# Altium schematic sheet GTL3_Inverter.SchDoc
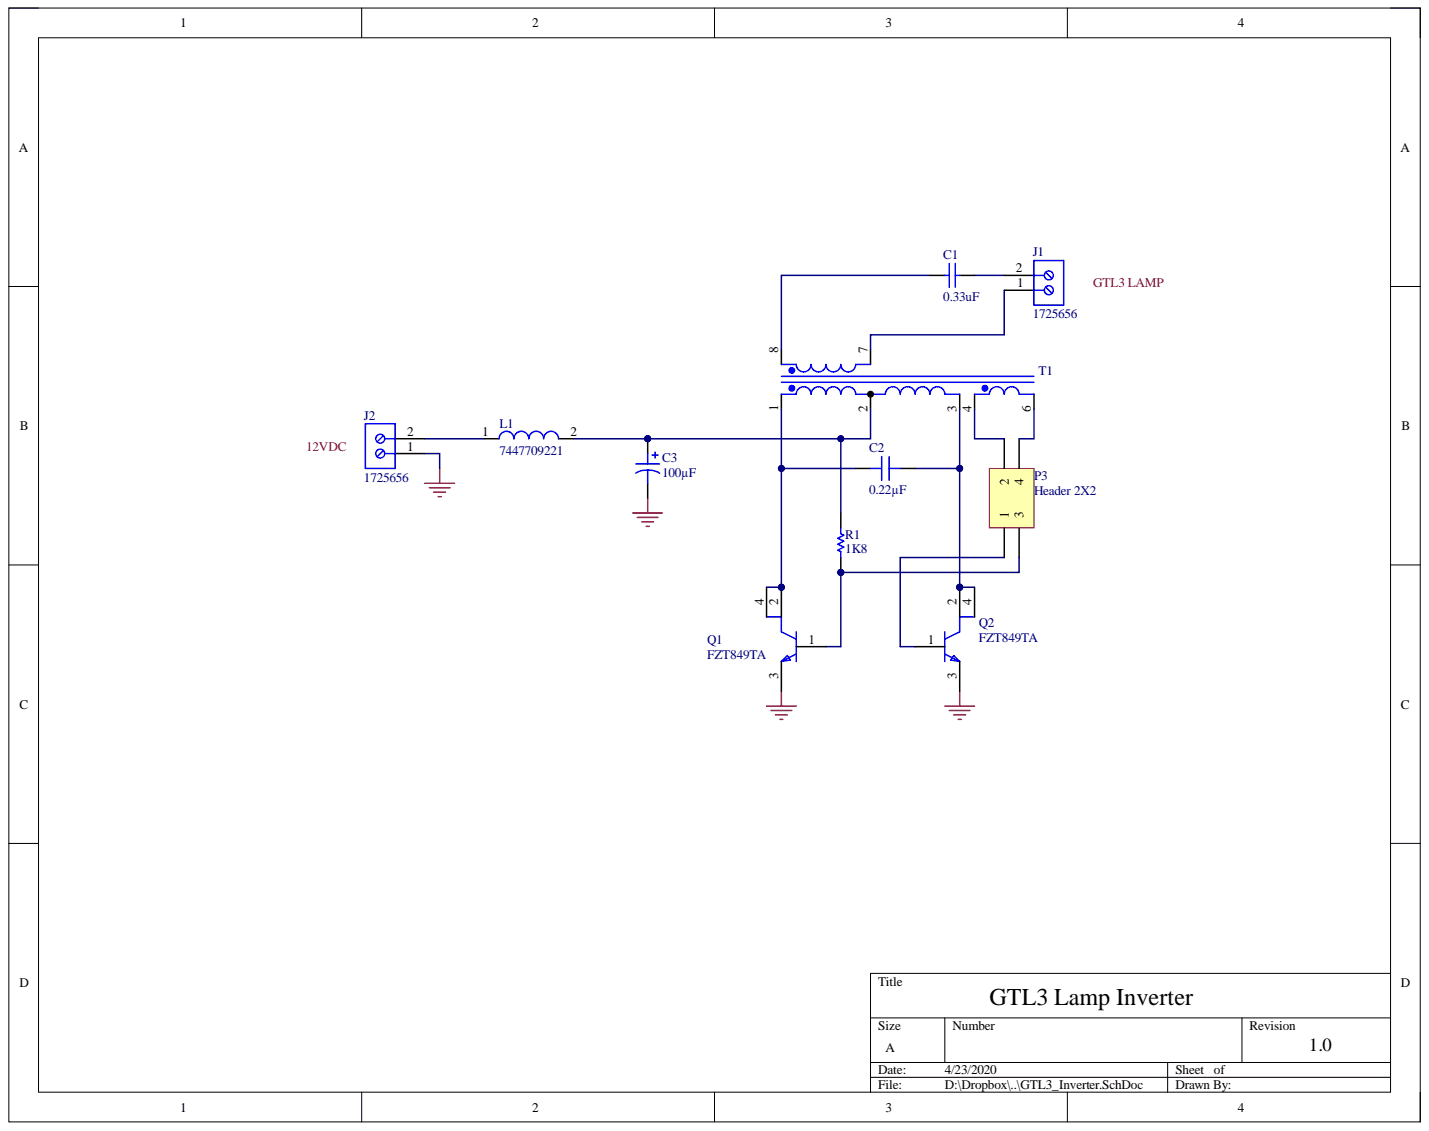
[diagram p0: GTL3_Inverter.SchDoc]

## Parts
C1  0.33uF  [CAPC2220(5750)230_L]
C2  0.22µF  [CAP FILM 2416]
C3  100µF  [CAP ALSMD 8.3MM H10.5MM]
J1  1725656  [PHOE-1725656-2]
J2  1725656  [PHOE-1725656-2]
L1  7447709221  [WE-PD-XXL]
P3  Header 2X2  [HDR2X2]
Q1  FZT849TA  [FP-SOT223-MFG]
Q2  FZT849TA  [FP-SOT223-MFG]
R1  1K8  [RESC0805(2012)_L]
T1  Trans5_Royer  [PCB1808B1]  (value not drawn)

## Nets
GND: C3.2 J2.1
NetC1_1: C1.1 T1.8
NetC1_2: C1.2 J1.2
NetC2_1: C2.1 Q2.2 Q2.4 T1.3
NetC2_2: C2.2 Q1.2 Q1.4 T1.1
NetC3_1: C3.1 L1.2 R1.2 T1.2
NetJ1_1: J1.1 T1.7
NetJ2_2: J2.2 L1.1
NetP3_2: P3.2 T1.4
NetP3_3: P3.3 R1.1
NetP3_4: P3.4 T1.6

Not connected: P3 1; Q1 1 3; Q2 1 3

## Library data of the parts
C1 = CMP-1590-00009-1: 2220 (5750 Metric) Chip Capacitor; Case-EIA: 2220; Case-Metric: 5750; Component Kind: Standard; Library Name: Altium Content Vault; PackageReference: CAPC2220(5750)230
C2 = ECH-U1H224GX9: CAP FILM 0.22UF 2% 50VDC 2416; Manufacturer: Panasonic Electronic Components; Database Table Name: Capacitors - Film; Dielectric Material: Polyphenylene Sulfide (PPS), Metallized - Stacked; Voltage Rating - DC: 50V; Applications: General Purpose; Capacitance: 0.22µF; Component Kind: Standard; ComponentLink1Description: Datasheet; ComponentLink1URL: http://industrial.panasonic.com/www-cgi/jvcr13pz.cgi?E+PZ+3+ABD0013+ECHU1H224GX9+7+WW; ComponentLink2Description: DigiKey Link; ComponentLink2URL: http://digikey.com/product-detail/en/panasonic-electronic-components/ECH-U1H224GX9/PCF1556CT-ND/1630919; Device Package: 2416 (6041 Metric); Height - Seated (Max): 0.118" (3.00mm); LastUpdated: 21/01/2020 3:58:38 AM; Library Name: Celestial Altium Library - altium_library.DbLib; Lifecycle Status: Active; Minimum Order: 1; Mounting Type: Surface Mount; Operating Temperature: -55°C ~ 125°C; Package / Case: 2416 (6041 Metric); Packaging: TapeAndReel; Part Number: ECH-U1H224GX9; Part Status: Active; Price: 0.48; Series: ECH-U(X); Size / Dimension: 0.236" L x 0.161" W (6.00mm x 4.10mm); Supplier 1: DigiKey; Supplier Part Number 1: PCF1556CT-ND; Termination: Solder Pads; Tolerance: ±2%; Value: 0.22µF
C3 = EDH107M050A9MAA: CAP ALUM SMD 105C 100UF 50VDC; Manufacturer: KEMET; Database Table Name: Capacitors - Aluminum - Electrolytic SMT; Lifetime @ Temp.: 2000 Hrs @ 105°C; Polarization: Polar; Ripple Current @ High Frequency: 300mA @ 10kHz; Ripple Current @ Low Frequency: 240mA @ 120Hz; Surface Mount Land Size: 0.327" L x 0.327" W (8.30mm x 8.30mm); Tolerance: ±20%; Voltage - Rated: 50V; Applications: General Purpose; Capacitance: 100µF; Component Kind: Standard; ComponentLink1Description: Datasheet; ComponentLink1URL: https://api.kemet.com/component-edge/download/datasheet/EDH107M050A9MAA.pdf; ComponentLink2Description: DigiKey Link; ComponentLink2URL: http://digikey.com/product-detail/en/kemet/EDH107M050A9MAA/399-18136-1-ND/9354337; Device Package: Radial, Can - SMD; Height - Seated (Max): 0.413" (10.50mm); LastUpdated: 21/01/2020 4:02:50 AM; Library Name: Celestial Altium Library - altium_library.DbLib; Lifecycle Status: Active; Minimum Order: 1; Mounting Type: Surface Mount; Operating Temperature: -40°C ~ 105°C; Package / Case: Radial, Can - SMD; Packaging: TapeAndReel; Part Number: EDH107M050A9MAA; Part Status: Active; PartId: 7635; Price: 0.22; Series: EDH; Size / Dimension: 0.315" Dia (8.00mm); Supplier 1: DigiKey; Supplier Part Number 1: 399-18136-1-ND; Value: 100µF
J1 J2 = CMP-2000-05299-1: Male Header, Pitch 2.54 mm, 1 x 2 Position, Height 9 mm, Tail Length 3.5 mm; Manufacturer: Phoenix Contact; Content: 250pc(s); Industrial packaging: Yes; Number of contacts: 2; Pitch: 2.54mm; Colour: Green; Component Kind: Standard; Datasheet URL: http://datasheet.octopart.com/1725656-Phoenix-Contact-datasheet-5302866.pdf; Datasheet Version: Apr-08; Library Name: Altium Content Vault; Manufacturer part No.: 1725656; Manufacturer URL: http://www.phoenixcontact.com/; Type: MPT0,5/2-2,54
L1 = CMP-0227-00173-1: SMD-Shielded Power Inductor WE-PD, L = 220 µH; AML Part Number: IND-S0011; Inductance: 220 µH; Component Kind: Standard; ComponentLink1Description: Manufacturer Link; ComponentLink1URL: http://www.we-online.com; ComponentLink2Description: Datasheet; ComponentLink2URL: http://www.we-online.de/katalog/media/pdf/7447709221.pdf; DatasheetVersion: ver 1, Oct-2004; Library Name: Altium Content Vault; PackageDescription: SMD Inductor, 2-Leads, Body 12.0 x 12.0 mm; PackageReference: WE-PD-XXL; PackageVersion: 2004; Supplier 1: Digi-Key; Supplier Part Number 1: 732-1696-6-ND
P3 = Header 2X2: Header, 2-Pin, Dual row; Component Kind: Standard; LatestRevisionDate: 17-Jul-2002; LatestRevisionNote: Re-released for DXP Platform.; Library Name: Miscellaneous Connectors.IntLib; Signal Integrity: Connector; Publisher: Altium Limited
Q1 Q2 = CMP-12798-000001-1: Bipolar (BJT) Transistor NPN 30V 7A 100MHz 3W Surface Mount SOT-223; Manufacturer: Diodes Inc.; Automotive Grade: Grade 0; Collector Base Voltage: 80V; Collector Emitter Breakdown Voltage: 120V; Collector Emitter Saturation Voltage: 350mV; Emitter Base Voltage: 7V; Hfe Max: 300; Hfe Min: 100; Hfe Testic: 1A; Hfe Testvce: 1V; Max Junction Temp: +150°C; Number Of Elements: 1; Power Dissipation: 1.6W; Rthja Max: 78°C/W; Standoff Height: 0.01mm; Automotive: Yes; Category: Trans; Collector Emitter Voltage: 30V; Component Kind: Standard; ComponentLink2Description: Datasheet; ComponentLink2URL: https://www.diodes.com/assets/Datasheets/FZT849.pdf; Device Class L1: Discrete Semiconductors; Device Class L2: Transistors; Device Class L3: BJTs; Digikey Description: TRANS NPN 30V 7A SOT-223; Footprint Url: https://www.diodes.com/assets/Package-Files/SOT223.pdf; Height: 1.8mm; Lead Free: Yes; Library Name: Altium Content Vault; Manufacturer Part Number: FZT849TA; Max Collector Current: 7A; Mouser Description: Bipolar Transistors - BJT NPN High Ct Low Sat; Number Of Npn: 1; Octopart: https://octopart.com/fzt849ta-diodes+inc.-356585; Package: SOT223; RoHS: TRUE; Temperature: -55°C to +150°C; Temperature Range High: +150°C; Temperature Range Low: -55°C; Unity Gain Freq: 100MHz
R1 = CMP-1013-00537-1: 1K8 0.125W 1% 0805 (2012 Metric)  SMD; AML Part Number: RES-S0005; Case-EIA: 0805; Case-Metric: 2012; Value: 1800.00 Ohm; Component Kind: Standard; Library Name: Altium Content Vault; Power: 0.125 W; Technology: SMT; Tolerance: 1 %
T1 = Trans5_Royer: Four-winding Transformer (Non-Ideal); Sim Note: Enter the turns ratios, Core loss resistance, Magnetising Reactance, Leakage Reactances and Resistances referred to the primary side into the parameter fields.; Component Kind: Standard; LatestRevisionDate: 17-Jul-2002; LatestRevisionNote: Re-released for DXP Platform.; Library Name: Miscellaneous Devices.IntLib; PackageDescription: Transformer; 8 Leads; PackageReference: TRF_8; Published: 25-Jan-2001; Simulation: NI4WTRANS; Publisher: Altium Limited
